# Altium schematic sheet MCU.SchDoc
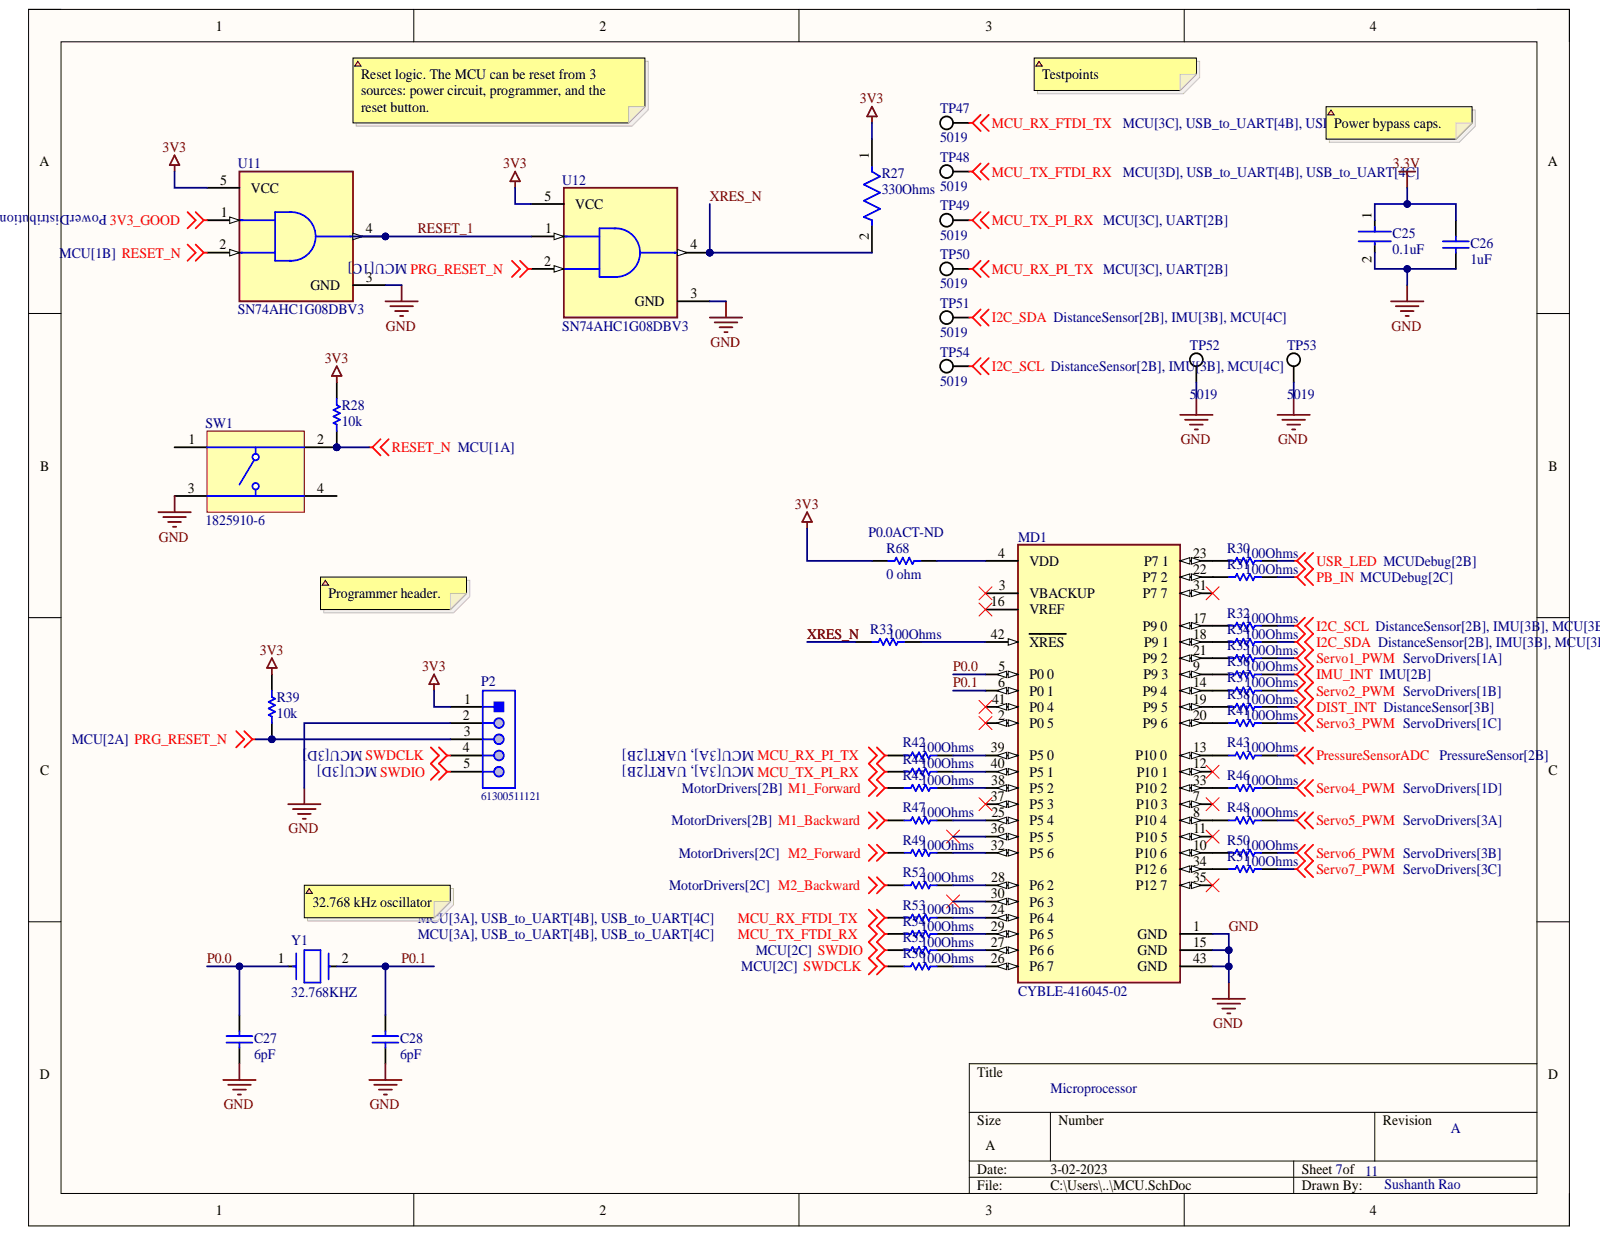
[diagram p0: MCU.SchDoc]

## Parts
C25  0.1uF  [FP-CC0805-0_85-MFG]
C26  1uF  [CAPC2013X145X50ML20T25]
C27  6pF  [CAPC2013X94X50LL20T25]
C28  6pF  [CAPC2013X94X50LL20T25]
MD1  CYBLE-416045-02  [FP-CYBLE-416045-02-MFG]
P2  61300511121  [61300511121]
R27  330Ohms  [FP-KTR10-IPC_C]
R28  10k  [RESC2013X60X35NL10T20]
R30  100Ohms  [RESC2013X60X35ML10T20]
R31  100Ohms  [RESC2013X60X35ML10T20]
R32  100Ohms  [RESC2013X60X35ML10T20]
R33  100Ohms  [RESC2013X60X35ML10T20]
R34  100Ohms  [RESC2013X60X35ML10T20]
R35  100Ohms  [RESC2013X60X35ML10T20]
R36  100Ohms  [RESC2013X60X35ML10T20]
R37  100Ohms  [RESC2013X60X35ML10T20]
R38  100Ohms  [RESC2013X60X35ML10T20]
R39  10k  [RESC2013X60X35NL10T20]
R41  100Ohms  [RESC2013X60X35ML10T20]
R42  100Ohms  [RESC2013X60X35ML10T20]
R43  100Ohms  [RESC2013X60X35ML10T20]
R44  100Ohms  [RESC2013X60X35ML10T20]
R45  100Ohms  [RESC2013X60X35ML10T20]
R46  100Ohms  [RESC2013X60X35ML10T20]
R47  100Ohms  [RESC2013X60X35ML10T20]
R48  100Ohms  [RESC2013X60X35ML10T20]
R49  100Ohms  [RESC2013X60X35ML10T20]
R50  100Ohms  [RESC2013X60X35ML10T20]
R51  100Ohms  [RESC2013X60X35ML10T20]
R52  100Ohms  [RESC2013X60X35ML10T20]
R53  100Ohms  [RESC2013X60X35ML10T20]
R54  100Ohms  [RESC2013X60X35ML10T20]
R55  100Ohms  [RESC2013X60X35ML10T20]
R56  100Ohms  [RESC2013X60X35ML10T20]
R68  0 ohm  Supplier Part Number 1: P0.0ACT-ND  [RESC2013X70X40NL20T20]
SW1  1825910-6  [TECO-1825910-6_V]
TP47  5019  [KSTN-5019_V]
TP48  5019  [KSTN-5019_V]
TP49  5019  [KSTN-5019_V]
TP50  5019  [KSTN-5019_V]
TP51  5019  [KSTN-5019_V]
TP52  5019  [KSTN-5019_V]
TP53  5019  [KSTN-5019_V]
TP54  5019  [KSTN-5019_V]
U11  SN74AHC1G08DBV3  [FP-DBV0005A-IPC_C]
U12  SN74AHC1G08DBV3  [FP-DBV0005A-IPC_C]
Y1  32.768KHZ  [FP-ABS07-120-MFG]

## Nets
3.3V: C25.1 C26.2
3V3: P2.1 R27.1 R28.2 R39.2 R68.1 U11.5(VCC) U12.5(VCC)
3V3_GOOD: U11.1
DIST_INT: R38.2
I2C_SCL: R32.2 TP54.1
I2C_SDA: R34.2 TP51.1
IMU_INT: R36.2
M1_Backward: R47.1
M1_Forward: R45.1
M2_Backward: R52.1
M2_Forward: R49.1
MCU_RX_FTDI_TX: R53.1 TP47.1
MCU_RX_PI_TX: R42.1 TP50.1
MCU_TX_FTDI_RX: R54.1 TP48.1
MCU_TX_PI_RX: R44.1 TP49.1
PB_IN: R31.2
PressureSensorADC: R43.2
PRG_RESET_N: P2.3 R39.1 U12.2
RESET_N: R28.1 SW1.2 U11.2
Servo1_PWM: R35.2
Servo2_PWM: R37.2
Servo3_PWM: R41.2
Servo4_PWM: R46.2
Servo5_PWM: R48.2
Servo6_PWM: R50.2
Servo7_PWM: R51.2
SWDCLK: P2.4 R56.1
SWDIO: P2.5 R55.1
USR_LED: R30.2
GND: C25.2 C26.1 C27.1 C28.1 MD1.1(GND) MD1.15(GND) MD1.43(GND) P2.2 SW1.3 TP52.1 TP53.1 U11.3(GND) U12.3(GND)
P0.0: C27.2 MD1.5(P0_0) Y1.1
P0.1: C28.2 MD1.6(P0_1) Y1.2
RESET_1: U11.4 U12.1
XRES_N: R27.2 R33.1 U12.4
NetMD1_4: MD1.4(VDD) R68.2
NetMD1_8: MD1.8(P10_4) R48.1
NetMD1_9: MD1.9(P9_3) R36.1
NetMD1_10: MD1.10(P10_6) R50.1
NetMD1_13: MD1.13(P10_0) R43.1
NetMD1_14: MD1.14(P9_4) R37.1
NetMD1_17: MD1.17(P9_0) R32.1
NetMD1_18: MD1.18(P9_1) R34.1
NetMD1_19: MD1.19(P9_5) R38.1
NetMD1_20: MD1.20(P9_6) R41.1
NetMD1_21: MD1.21(P9_2) R35.1
NetMD1_22: MD1.22(P7_2) R31.1
NetMD1_23: MD1.23(P7_1) R30.1
NetMD1_24: MD1.24(P6_4) R53.2
NetMD1_25: MD1.25(P5_4) R47.2
NetMD1_26: MD1.26(P6_7) R56.2
NetMD1_27: MD1.27(P6_6) R55.2
NetMD1_28: MD1.28(P6_2) R52.2
NetMD1_29: MD1.29(P6_5) R54.2
NetMD1_32: MD1.32(P5_6) R49.2
NetMD1_33: MD1.33(P10_2) R46.1
NetMD1_34: MD1.34(P12_6) R51.1
NetMD1_38: MD1.38(P5_2) R45.2
NetMD1_39: MD1.39(P5_0) R42.2
NetMD1_40: MD1.40(P5_1) R44.2
NetMD1_42: MD1.42(XRES) R33.2

Not connected: MD1 2(P0_5) 3(VBACKUP) 7(P10_3) 11(P10_5) 12(P10_1) 16(VREF) 30(P6_3) 31(P7_7) 35(P12_7) 36(P5_5) 37(P5_3) 41(P0_4); SW1 1 4

## Library data of the parts
C25 = CMP-1036-04410-2: CAP CER 0.1UF 25V X7R 0805; Manufacturer: Yageo; Manufacturer Part Number: CC0805KRX7R8BB104; Capacitance: 100 nF; Insulation Resistance: 100GR; Material: Ceramic; Tolerance: 0.1; Value: 100 nF; Automotive: No; Case Code (Imperial): 0805; Case Code (Metric): 2012; Case/Package: 0805; Category: Cap; Component Kind: Standard; ComponentLink1Description: https://datasheet.ciiva.com/8034/70765-8034031.pdf?src-supplier=Element14; ComponentLink1URL: https://datasheet.ciiva.com/8034/70765-8034031.pdf?src-supplier=Element14; ComponentLink2Description: Datasheet; ComponentLink2URL: http://www.yageo.com/documents/recent/UPY-GPHC_Y5V_6.3V-to-50V_8.pdf; ComponentLink3Description: https://datasheet.ciiva.com/28450/1489800-28450789.pdf?src-supplier=Element14; ComponentLink3URL: https://datasheet.ciiva.com/28450/1489800-28450789.pdf?src-supplier=Element14; ComponentLink4Description: https://datasheet.ciiva.com/46430/1850775-46430166.pdf?src-supplier=Element14; ComponentLink4URL: https://datasheet.ciiva.com/46430/1850775-46430166.pdf?src-supplier=Element14; ComponentLink5Description: https://datasheet.ciiva.com/145/mlccorderingcode-145008.pdf?src-supplier=Digi-Key; ComponentLink5URL: https://datasheet.ciiva.com/145/mlccorderingcode-145008.pdf?src-supplier=Digi-Key; ComponentLink6Description: https://datasheet.ciiva.com/145/mlccorderingcode-145007.pdf?src-supplier=Digi-Key; ComponentLink6URL: https://datasheet.ciiva.com/145/mlccorderingcode-145007.pdf?src-supplier=Digi-Key; ComponentLink7Description: https://datasheet.ciiva.com/145/mlccorderingcode-145009.pdf?src-supplier=Digi-Key; ComponentLink7URL: https://datasheet.ciiva.com/145/mlccorderingcode-145009.pdf?src-supplier=Digi-Key; ComponentLink8Description: https://datasheet.ciiva.com/8034/1784427-8034030.pdf?src-supplier=Element14; ComponentLink8URL: https://datasheet.ciiva.com/8034/1784427-8034030.pdf?src-supplier=Element14; Depth: 1.25mm; Device Class L1: Passive Components; Device Class L2: Capacitors; Device Class L3: Ceramic Capacitors; Dielectric: X7R; Digikey Description: CAP CER 0.1UF 25V X7R 0805; Footprint Url: http://www.yageo.com/exep/pages/download/literatures/UPY-C_GEN_24.pdf; Halogen Free: Halogen Free; Height: 0.95mm; Ipc Land Pattern Name: CAPC200125X85; Lead Free: Yes; Length: 2mm; Library Name: Altium Content Vault; Max Operating Temperature: 125°C; Min Operating Temperature: -55°C; Mount: Surface Mount; Mouser Description: Multilayer Ceramic Capacitors MLCC - SMD/SMT 100nF 25V X7R 10%; Number of Pins: 2; Octopart: https://octopart.com/cc0805krx7r8bb104-yageo-39467766; Package: CC0805-0.85; Package Quantity: 4000; Packaging: Tape and Reel; Pricing 1: 1=0.11000, 10=0.07700, 50=0.04380, 100=0.03620, 500=0.02542, 1000=0.02104 (USD); Radiation Hardening: No; Reach SVHC Compliant: Yes; Resistor Type: Ceramic; RoHS: TRUE; RoHS Compliant: Yes; Series: CC; Stock 1: 1013369; Supplier 1: Digi-Key; Supplier Part Number 1: 311-1141-1-ND; Temperature: -30°C to +85°C; Temperature Characteristic: X7R; Temperature Range High: +85°C; Temperature Range Low: -30°C; Termination: SMD/SMT; Thickness: 0.033inch; Voltage Rating: 25 V; Voltage Rating (DC): 25V; Width: 1.25mm
C26 = CMP-1036-04757-2: Manufacturer: Yageo; Manufacturer Part Number: CC0805ZRY5V8BB105; Dielectric: Y5V; Tolerance: 80%; Voltage Rating: 25V; Capacitance: 1uF; Case Code (Imperial): 0805; Case Code (Metric): 2012; Case/Package: 0805; Category: Ceramic Capacitors; Component Kind: Standard; ComponentLink1Description: https://datasheet.ciiva.com/144/mlccorderingcode-144703.pdf?src-supplier=Digi-Key; ComponentLink1URL: https://datasheet.ciiva.com/144/mlccorderingcode-144703.pdf?src-supplier=Digi-Key; ComponentLink2Description: https://datasheet.ciiva.com/26863/getdatasheetpartid-419810-26863011.pdf?src-supplier=Verical; ComponentLink2URL: https://datasheet.ciiva.com/26863/getdatasheetpartid-419810-26863011.pdf?src-supplier=Verical; ComponentLink3Description: https://datasheet.ciiva.com/28450/1132289-28450860.pdf?src-supplier=Element14; ComponentLink3URL: https://datasheet.ciiva.com/28450/1132289-28450860.pdf?src-supplier=Element14; ComponentLink4Description: https://datasheet.ciiva.com/144/mlccorderingcode-144704.pdf?src-supplier=Digi-Key; ComponentLink4URL: https://datasheet.ciiva.com/144/mlccorderingcode-144704.pdf?src-supplier=Digi-Key; ComponentLink5Description: https://datasheet.ciiva.com/144/mlccorderingcode-144705.pdf?src-supplier=Digi-Key; ComponentLink5URL: https://datasheet.ciiva.com/144/mlccorderingcode-144705.pdf?src-supplier=Digi-Key; ComponentLink6Description: https://datasheet.ciiva.com/13208/upy-gp-np0-16v-to-50v-8-13208251.pdf?src-supplier=Mouser; ComponentLink6URL: https://datasheet.ciiva.com/13208/upy-gp-np0-16v-to-50v-8-13208251.pdf?src-supplier=Mouser; Depth: 1.25mm; Halogen Free: Halogen Free; Height: 0.85mm; Lead Free: Lead Free; Length: 2mm; Library Name: Altium Content Vault; Max Operating Temperature: 85°C; Min Operating Temperature: -30°C; Mount: Surface Mount; Package Quantity: 1; Packaging: Tape and Reel; Part Number: CC0805ZRY5V8BB105; Pricing 1: 1=0.13000, 10=0.09000, 50=0.05100, 100=0.04230, 500=0.02966, 1000=0.02456 (USD); Radiation Hardening: No; Reach SVHC Compliant: Yes; Resistor Type: Ceramic; RoHS: Yes; RoHS Compliant: Yes; Series: CC; Stock 1: 286163; Supplier 1: Digi-Key; Supplier Part Number 1: 311-1358-1-ND; Termination: SMD/SMT; Thickness: 0.033inch; Voltage Rating (DC): 25V; Weight: 0.000194oz; Width: 1.25mm
C27 C28 = CMP-2007-04344-1: Manufacturer: AVX Interconnect / Elco; Part Number: 08055A6R0CAT2A; Component Kind: Standard; Library Name: Altium Content Vault
MD1 = CMP-12964-000002-1: RF TXRX MOD BLUETOOTH TRACE ANT; Manufacturer: Cypress Semiconductor; Bandwidth: 100MHz; Data Rate: 2Mbits/s; Interface: I2C,SPI,UART,I2S,Other; Max Frequency: 2.5GHz; Max Supply Voltage: 3.6V; Min Supply Voltage: 1.71V; Number Of Adc Channels: 1; Number Of Gpio: 36; Receiving Current: 6.7mA; Transmitting Current: 5.7mA; Automotive: No; Category: UNK; Component Kind: Standard; ComponentLink2Description: Datasheet; ComponentLink2URL: https://www.cypress.com/file/428336/download; Device Class L1: Integrated Circuits (ICs); Device Class L2: RF Semiconductors and Devices; Device Class L3: Transceivers; Digikey Description: RF TXRX MOD BLUETOOTH TRACE ANT; Height: 2mm; Lead Free: Yes; Library Name: Altium Content Vault; Manufacturer Part Number: CYBLE-416045-02; Octopart: https://octopart.com/cyble-416045-02-cypress+semiconductor-96386825; Package: 0; RoHS: TRUE; Temperature: -40°C to +85°C; Temperature Range High: +85°C; Temperature Range Low: -40°C
P2 = CMP-1502-01068-1: THT Vertical Pin Header WR-PHD, Pitch 2.54 mm, Single Row, 5 pins; Manufacturer: Wurth Elektronik; Contact Resistance: 20mOhm; Gender: Male; Pin Number: 5; Pitch: 2.54mm; Rated Current: 3A; Withstanding Voltage: 500VAC; Working Voltage: 250VAC; Component Kind: Standard; Datasheet URL: https://katalog.we-online.de/em/datasheet/6130xx11121.pdf; Library Name: Altium Content Vault; Manufacturer URL: http://www.we-online.de; Mounting Technology: THT; Operating Temperature: -40to105degC; Orientation: Vertical; Package Reference: 61300511121; Packaging: Bag; Part Number: 61300511121; Row Number: Single; Publisher: WurthElektronik
R27 = CMP-08839-031445-1: Res Thick Film 0805 300Ω 5% 0.125W ±200ppm/°C Automotive Paper T/R; Max Power Dissipation: 125mW; Resistance: 300R; Case Code (Imperial): 0805; Case Code (Metric): 2012; Case/Package: 0805; Component Kind: Standard; Features: Automotive AEC-Q200; Height: 0.026inch; Length: 0.079inch; Library Name: Altium Content Vault; Max Operating Temperature: 155°C; Min Operating Temperature: -55°C; Number of Terminations: 2; Package Quantity: 1; Packaging: Cut Tape; Resistor Type: Thick Film; RoHS Compliant: Yes; Series: KTR; Temperature Coefficient: 200ppm/°C; Tolerance: 5%; Width: 0.049inch
R28 R39 = CMP-1659-00013-4: Chip Resistor, 10 KOhm, +/- 1%, 0.125 W, -55 to 155 degC, 0805 (2012 Metric), RoHS, Tape and Reel; Manufacturer: Yageo; Manufacturer Part Number: RC0805FR-0710KL; Max Power Dissipation: 125mW; Temperature Coefficient: 100ppm/°C; Value: 10kR; Voltage Rating: 150 V; Case Code (Imperial): 0805; Case Code (Metric): 2012; Case/Package: 0805; Category: Chip SMD Resistors; Component Kind: Standard; ComponentLink1Description: Manufacturer URL; ComponentLink1URL: http://www.yageo.com/; ComponentLink2Description: Datasheet; ComponentLink2URL: http://www.yageo.com/documents/recent/PYu-RC_Group_51_RoHS_L_04.pdf; Construction: Rectangular; DatasheetVersion: Rev. 4, 02/2016; Depth: 1.25mm; Features: Moisture Resistant; Height: 0.5 mm; Lead Free: Lead Free; Length: 2 mm; Library Name: Altium Content Vault; Max Operating Temperature: 155 degC; Military Standard: Not; Min Operating Temperature: -55 degC; Mount: Surface Mount; Mounting Technology: Surface Mount; Number of Terminations: 2; Package Quantity: 5000; PackageDescription: 2-Pin Surface Mounted Device, Body 2 x 1.25 mm; PackageReference: 0805; Packaging: Tape and Reel; Pins: 2; Power Rating: 125mW; Pricing 1: 1=0.10000, 10=0.03300, 100=0.01340, 1000=0.00602, 2500=0.00523 (USD); REACH SVHC: No SVHC; Resistance Tolerance: 1%; Resistor Type: Thick Film; RoHS: Yes; RoHS Compliant: Yes; RoHSCompliant: true; Series: RC; Stock 1: 11227289; Supplier 1: Digi-Key; Supplier Part Number 1: 311-10.0KCRCT-ND; Technology: THICK FILM; Termination: SMD/SMT; Tolerance: 1%; Width: 0.049inch
R30-R38 R41-R56 = CMP-2100-03669-1: Chip Resistor, 100 Ohm, +/-1%, 0.125 W, -55 to 155 degC, 0805 (2012 Metric), RoHS, Tape and Reel; Manufacturer: Yageo; Max Power Dissipation: 125mW; Resistance: 100Ohm; Temperature Coefficient: 100ppm/degreesC; Tolerance: 1%; Voltage Rating: 150V; Case Code (Imperial): 0805; Case Code (Metric): 2012; Case/Package: 0805; Component Kind: Standard; Composition: ThickFilm; Datasheet URL: http://www.yageo.com/NewPortal/yageodocoutput?fileName=/pdf/R-Chip/PYu-RC_Group_51_RoHS_L_5.pdf; Datasheet Version: Rev. 5, 10/2016; Depth: 1.25mm; Height: 0.024inch; Lead Free: LeadFree; Lead Pitch: 0.4mm; Length: 2mm; Library Name: Altium Content Vault; Manufacturer URL: http://www.yageo.com/; Max Operating Temperature: 155degC; Military Standard: Not; Min Operating Temperature: -55degC; Number of Pins: 2; Number of Terminations: 2; Package Description: 2-Pin Surface Mounted Device, Body 2 x 1.25 mm; Package Quantity: 1; Package Reference: 0805; Packaging: TapeandReel; Power Rating: 125mW; REACH SVHC: Unknown; RoHSCompliant: true; Termination: SMD/SMT; Voltage Rating (DC): 150V; Width: 0.049inch
R68 = CMP-1665-00002-5: Chip Resistor, 0 Ohm, +/- 1%, 0.125 W, -55 to 155 degC, 0805 (2012 Metric), RoHS, Tape and Reel; Manufacturer: Panasonic; Manufacturer Part Number: ERJ-6GEY0R00V; Current Rating: 2A; Max Power Dissipation: 125mW; Temperature Coefficient: 600ppm/°C; Tolerance: 5%; Value: 0R; Voltage Rating: 150V; Case Code (Imperial): 0805; Case Code (Metric): 2012; Case/Package: 0805; Category: Chip SMD Resistors; Component Kind: Standard; ComponentLink1Description: Manufacturer URL; ComponentLink1URL: http://www.panasonic.com/; ComponentLink2Description: Datasheet; ComponentLink2URL: http://industrial.panasonic.com/cdbs/www-data/pdf/RDA0000/AOA0000C84.pdf; Construction: Rectangular; Contact Plating: Tin; DatasheetVersion: 03/2015; Depth: 1.25mm; Features: Automotive AEC-Q200; Height: 0.6 mm; Lead Free: Lead Free; Length: 2 mm; Library Name: Altium Content Vault; Max Operating Temperature: 155 degC; Military Standard: Not; Min Operating Temperature: -55 degC; Mounting Technology: Surface Mount; Number of Terminations: 2; Package Quantity: 5000; PackageDescription: 2-Pin Surface Mount Device, Body 2 x 1.25 mm; Packaging: Tape and Reel; PartNumber: ERJ-6GEY0R00V; Power Rating: 125mW; Pricing 1: 1=0.10000, 10=0.06400, 25=0.04680, 50=0.03560, 100=0.02620, 250=0.02000, 500=0.01598, 1000=0.01177 (USD); Radiation Hardening: No; Ratings: AEC-Q200; REACH SVHC: No SVHC; Reach SVHC Compliant: Yes; Resistance: 0 Ohm; Resistor Type: Thick Film; RoHS: Yes; RoHS Compliant: Yes; RoHSCompliant: True; Series: ERJ; Stock 1: 3172332; Supplier 1: Digi-Key; Technology: THICK FILM; Termination: SMD/SMT; Voltage Rating (DC): 150V; Width: 1.25 mm
SW1 = CMP-1684-00021-1: Tact Switch, SPST-NO, 0.05 A, -35 to 85 degC, 4-Pin THD, RoHS, Bulk; Manufacturer: TE Connectivity; Actuator Color: Black; Actuator Length: 1.4mm; Actuator Material: PolybutyleneTerephthalate; Actuator Style: RoundButton; Body Orientation: Straight; Bushing Type: Unthreaded; Contact Rating @ Voltage: 0.05A@24VDC; Dielectric Strength: 500VAC; Electrical Life: 100000Cycles; Function: MomentaryContact; Illumination: N/R; Maximum Contact Resistance: 100mOhm; Maximum DC Voltage Rating: 24V; Pole Throw Configuration: SPST; Switch Configuration: OFF(ON); Base Material: N/A; Component Kind: Standard; Contact Material: CopperAlloy; Contact Plating: Silver; Datasheet URL: http://www.te.com/commerce/DocumentDelivery/DDEController?Action=showdoc&DocId=Customer+Drawing%7F1825910%7FC9%7Fpdf%7FEnglish%7FENG_CD_1825910_C9.pdf%7F1825910-6; Datasheet Version: Rev. C9; Dimension: 5.99x5mm; Family: 1825910; Housing Material: N/A; Library Name: Altium Content Vault; Manufacturer URL: http://www.te.com; Maximum AC Voltage Rating: N/A; Mechanical Life: 100000Cycles; Minimum Insulation Resistance: 100MOhm; Mounting: ThroughHole; Operating Temperature: -35to85C; Package Description: 4-Pin Through Hole Device, Body 5.99 x 5.99 mm, Pitch 6.5 mm; Package Reference: THD; Packaging: N/A; Part No: 1825910-6; Product Weight: N/A; RoHS: Yes; Seal Description: N/A; Seal Location: N/A; Terminal Type: PCPins; Thread Size: N/R
TP47-TP54 = CMP-1672-00008-1: Test Point, 1 Position SMD, RoHS, Tape and Reel; Manufacturer: Keystone Electronics; Body Material: Bronze; Plating: Silver; Category: Connectors; Component Kind: Standard; ComponentLink1Description: Manufacturer URL; ComponentLink1URL: http://www.keyelco.com/; ComponentLink2Description: Datasheet; ComponentLink2URL: http://www.keyelco.com/product-pdf.cfm?p=1357; ComponentLink3Description: https://datasheet.ciiva.com/16885/70182998-16885945.pdf?src-supplier=Allied+Electronics; ComponentLink3URL: https://datasheet.ciiva.com/16885/70182998-16885945.pdf?src-supplier=Allied+Electronics; ComponentLink4Description: https://datasheet.ciiva.com/14848/m60-2p61-14848437.pdf?src-supplier=Digi-Key; ComponentLink4URL: https://datasheet.ciiva.com/14848/m60-2p61-14848437.pdf?src-supplier=Digi-Key; ComponentLink5Description: https://datasheet.ciiva.com/14848/m60-2p61-14848438.pdf?src-supplier=Digi-Key; ComponentLink5URL: https://datasheet.ciiva.com/14848/m60-2p61-14848438.pdf?src-supplier=Digi-Key; ComponentLink6Description: https://datasheet.ciiva.com/14848/m60-2p61-14848439.pdf?src-supplier=Digi-Key; ComponentLink6URL: https://datasheet.ciiva.com/14848/m60-2p61-14848439.pdf?src-supplier=Digi-Key; ComponentLink7Description: https://datasheet.ciiva.com/16318/m60-2p61-16318395.pdf?src-supplier=Future+Electronics; ComponentLink7URL: https://datasheet.ciiva.com/16318/m60-2p61-16318395.pdf?src-supplier=Future+Electronics; Contact Material: Bronze; Contact Plating: Silver; DatasheetVersion: 03/2014; Height: 0.09inch; Lead Free: Lead Free; Library Name: Altium Content Vault; Manufacturer Part Number: 5019; Material: Bronze; Mount: Surface Mount; Mounting Technology: Surface Mount; Number of Pins: 1; Package Quantity: 1000; PackageDescription: 1-Pin Surface Mount Device, Body 3.81 x 2.03 mm; PackageReference: 5019; Packaging: Tape and Reel; Pins: 1; Pricing 1: 1=0.34000, 25=0.27360, 100=0.22340, 250=0.20064, 500=0.19152 (USD); Reach SVHC Compliant: Yes; RoHS: Yes; RoHS Compliant: Yes; RoHSCompliant: True; Series: 5019; Stock 1: 1009631; Supplier 1: Digi-Key; Supplier Part Number 1: 36-5019CT-ND; Termination: SMD/SMT
U11 U12 = CMP-04903-000082-1: IC GATE AND 1CH 2-INP SOT23-5; Logic Function: AND GATE; Component Kind: Standard; Library Name: Altium Content Vault; RoHS Compliant: Yes
Y1 = CMP-2000-07587-2: CRYSTAL 32.7680KHZ 6PF SMD; ESR (Equivalent Series Resistance): 55kR; Frequency Tolerance: 20ppm; Series Resistance: 60kR; Case/Package: SMD/SMT; Component Kind: Standard; Depth: 1.5mm; Frequency: 32.768kHz; Height: 0.035inch; Lead Free: Lead Free; Length: 3.2mm; Library Name: Altium Content Vault; Load Capacitance: 6pF; Max Operating Temperature: 85°C; Min Operating Temperature: -40°C; Mount: Surface Mount; Number of Pins: 2; Operating Frequency: 32.768 kHz; Package Quantity: 3000; Packaging: Tape and Reel; Radiation Hardening: No; REACH SVHC: No SVHC; RoHS Compliant: Yes; Series: ABS07; Termination: SMD/SMT; Tolerance: 20ppm; Width: 0.061inch
